# Altium schematic sheet ArduinoUnoShield.SchDoc
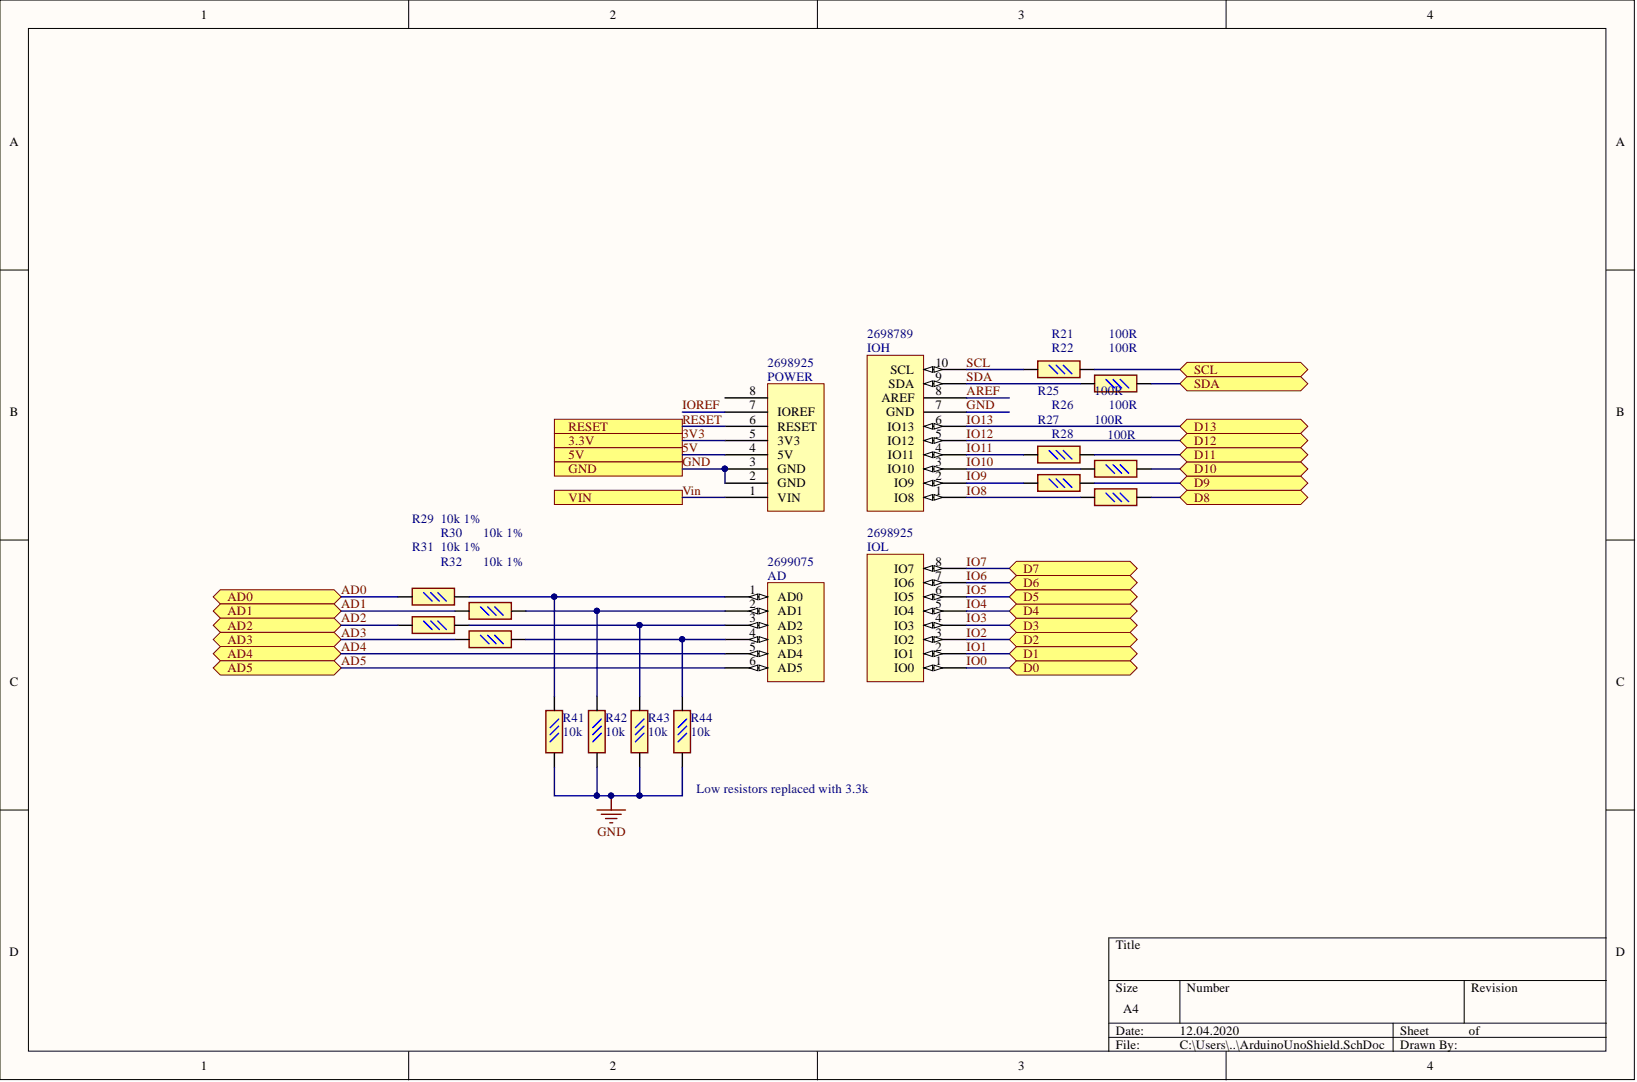
[diagram p0: ArduinoUnoShield.SchDoc]

## Parts
AD  AD  Supplier Part Number 4: 2699075  [Header 6x1 8.5mm]
IOH  IOH  Supplier Part Number 3: 2698789  [Header 10x1 8.5mm]
IOL  IOL  Supplier Part Number 4: 2698925  [Header 8x1 8.5mm]
POWER  Supplier Part Number 4: 2698925  [Header 8x1 8.5mm]  (hidden comment: POWER_UNO)
R21  100R  [0603r]
R22  100R  [0603r]
R25  100R  [0603r]
R26  100R  [0603r]
R27  100R  [0603r]
R28  100R  [0603r]
R29  10k 1%  value 10k  [0603r]
R30  10k 1%  value 10k  [0603r]
R31  10k 1%  [0603r]  (value not drawn)
R32  10k 1%  value 10k  [0603r]
R41  10k  [0603r]
R42  10k  [0603r]
R43  10k  [0603r]
R44  10k  [0603r]

## Ports
3.3V, 5V, AD0, AD1, AD2, AD3, AD4, AD5, D0, D1, D2, D3, D4, D5, D6, D7, D8, D9, D10, D11, D12, D13, GND, RESET, SCL, SDA, VIN

## Nets
3V3: POWER.5(3V3) ; port 3.3V
5V: POWER.4(5V) ; port 5V
AD0: R29.2 ; port AD0
AD1: R30.2 ; port AD1
AD2: R31.2 ; port AD2
AD3: R32.2 ; port AD3
AD4: AD.5(AD4) ; port AD4
AD5: AD.6(AD5) ; port AD5
AREF: IOH.8(AREF)
D8: R28.1 ; port D8
D9: R27.1 ; port D9
D10: R26.1 ; port D10
D11: R25.1 ; port D11
GND: IOH.7(GND) POWER.2(GND) POWER.3(GND) R41.2 R42.2 R43.2 R44.2 ; port GND
IO0: IOL.1(IO0) ; port D0
IO1: IOL.2(IO1) ; port D1
IO2: IOL.3(IO2) ; port D2
IO3: IOL.4(IO3) ; port D3
IO4: IOL.5(IO4) ; port D4
IO5: IOL.6(IO5) ; port D5
IO6: IOL.7(IO6) ; port D6
IO7: IOL.8(IO7) ; port D7
IO8: IOH.1(IO8) R28.2
IO9: IOH.2(IO9) R27.2
IO10: IOH.3(IO10) R26.2
IO11: IOH.4(IO11) R25.2
IO12: IOH.5(IO12) ; port D12
IO13: IOH.6(IO13) ; port D13
IOREF: POWER.7(IOREF)
RESET: POWER.6(RESET) ; port RESET
SCL: IOH.10(SCL) R21.1 R21.2 ; port SCL
SDA: IOH.9(SDA) R22.1 R22.2 ; port SDA
Vin: POWER.1(VIN) ; port VIN
NetAD_1: AD.1(AD0) R29.1 R41.1
NetAD_2: AD.2(AD1) R30.1 R42.1
NetAD_3: AD.3(AD2) R31.1 R43.1
NetAD_4: AD.4(AD3) R32.1 R44.1

Not connected: POWER 8

## Library data of the parts
R21 R22 R25-R28 = 100R 0603 1%: Company: Yageo; ID: B112CV1117V; Order Code: RC0603FR-07100RL; TSKD: FS-CM_StandDet_Resistor_SMD(100R_1%_100_0603); Component Kind: Standard; Description: 100R 0603 1%; Library Name: RESISTORs_Home.SchLib; Supplier 1: Digi-Key; Supplier Part Number 1: 311-100HRCT-ND; Type: Resistor; Value: 100R
R29-R32 = 10k 0603 1%: Company: Yageo; ID: B112CV1113U; Order Code: RC0603FR-0710K; TSKD: FS-CM_StandDet_Resistor_SMD(10K_1%_100_0603); Component Kind: Standard; Description: 10k 0603 1%; Library Name: RESISTORs_Home.SchLib; Supplier 1: Newark; Supplier Part Number 1: 68R0049; Type: Resistor
  R29 R31: Value: 10k
R41-R44 = 10k 0603 5%: Company: Yageo; ID: B112CV1113Y; Order Code: RC0603JR-0710KL; TSKD: FS-CM_StandDet_Resistor_SMD(10K_5%_100_0603); Component Kind: Standard; Description: 10k 0603 5%; Library Name: RESISTORs_Home.SchLib; Supplier 1: Digi-Key; Supplier Part Number 1: 311-10KGRCT-ND; Type: Resistor; Value: 10k
AD = AD: Component Kind: Standard; Library Name: Header.SchLib; Supplier 1: Arrow; Supplier 2: Digi-Key; Supplier 3: Arrow; Supplier 4: Arrow; Supplier Part Number 1: 2699089; Supplier Part Number 2: SAM1198-06-ND; Supplier Part Number 3: 2699088
IOH = IOH: Component Kind: Standard; Library Name: Header.SchLib; Supplier 1: Arrow; Supplier 2: Digi-Key; Supplier 3: Arrow; Supplier Part Number 1: 2698803S4475693N1004; Supplier Part Number 2: SAM1198-10-ND
IOL = IOL: Component Kind: Standard; Library Name: Header.SchLib; Supplier 1: Digi-Key; Supplier 2: Arrow; Supplier 3: Arrow; Supplier 4: Arrow; Supplier Part Number 1: SAM1198-08-ND; Supplier Part Number 2: 2698939; Supplier Part Number 3: 2698940
POWER = POWER_UNO: Component Kind: Standard; Library Name: Header.SchLib; Supplier 1: Digi-Key; Supplier 2: Arrow; Supplier 3: Arrow; Supplier 4: Arrow; Supplier Part Number 1: SAM1198-08-ND; Supplier Part Number 2: 2698939; Supplier Part Number 3: 2698940
